# Altium schematic sheet Balance_Circuit.SchDoc
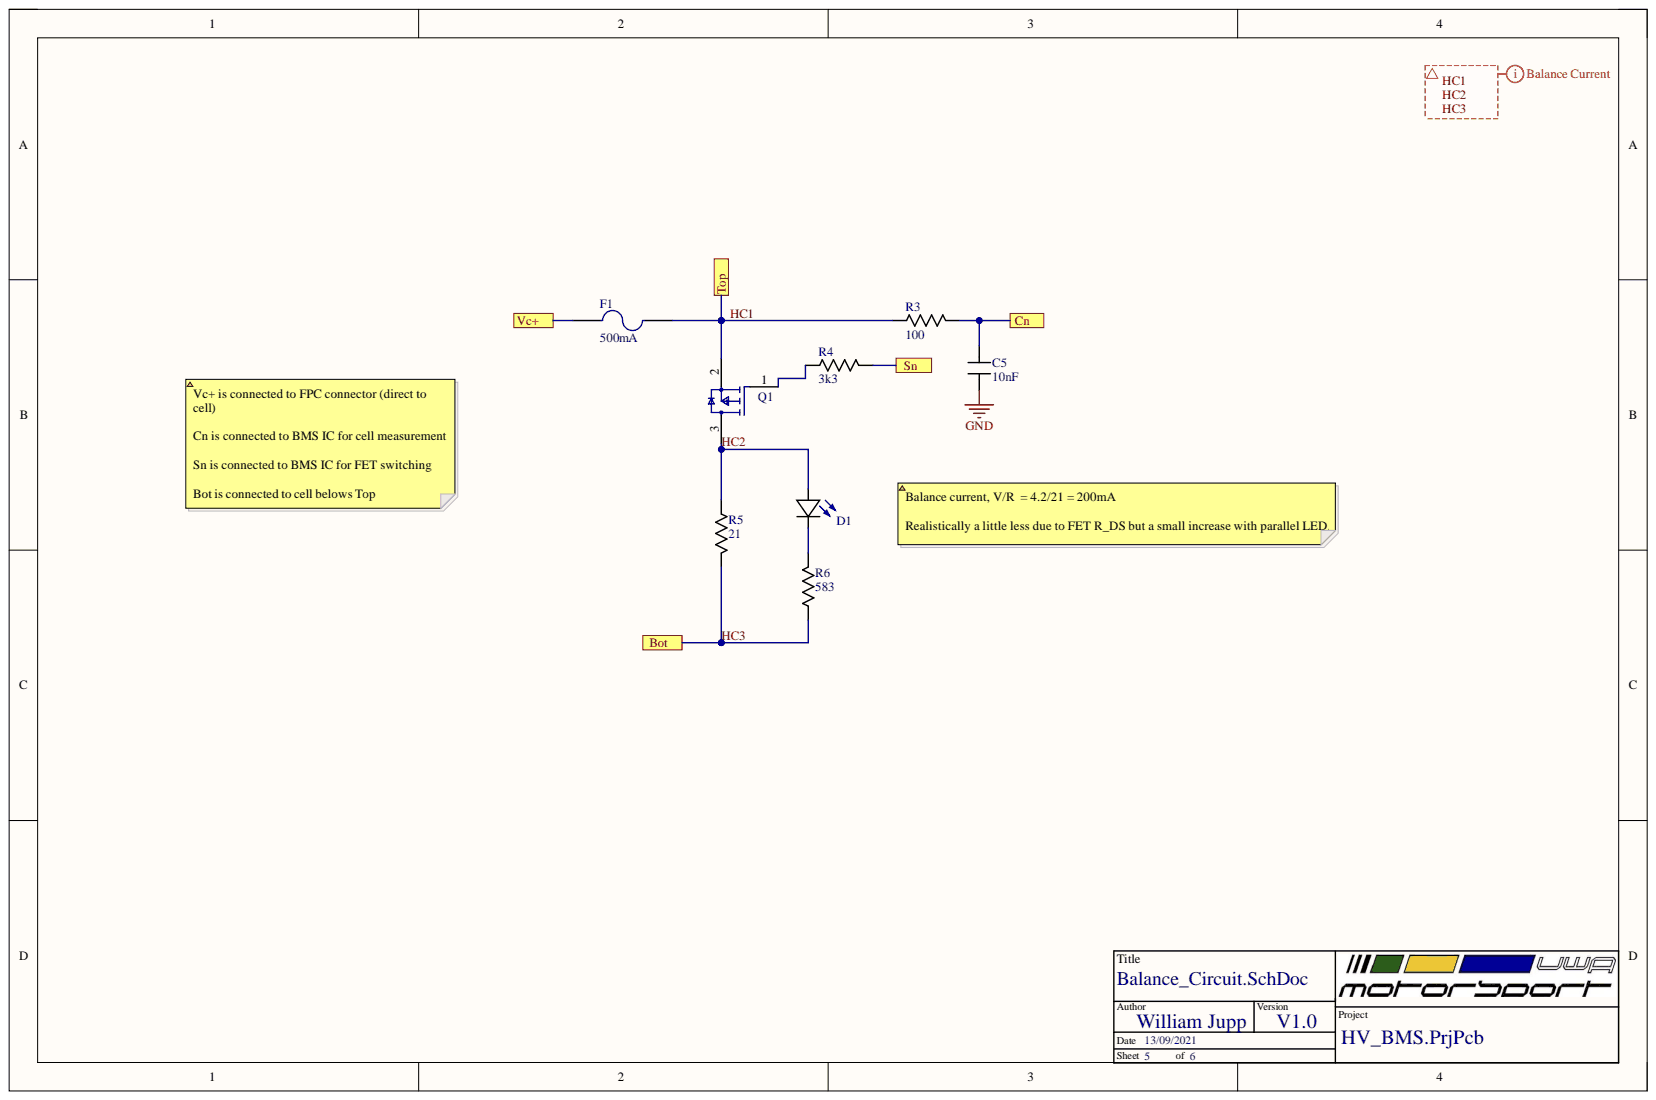
[diagram p0: Balance_Circuit.SchDoc]

## Parts
C5  C  value 10nF  [C_0603]
D1  150060BS55040  [LED (0603)]  (value not drawn)
F1  Current Rating: 500mA  [0466.500NR]  (hidden comment: 0466.500NR)
Q1  NX2301P,215  [FP-SOT23-MFG]  (value not drawn)
R3  value 100  [R-0603]  (hidden comment: Resistor)
R4  value 3k3  [R-0603]  (hidden comment: Resistor)
R5  value 21  [R_2512]  (hidden comment: CRCW251221R0FKEGHP)
R6  value 583  [R-0603]  (hidden comment: RT0603DRE07583RL)

## Ports
Bot, Cn, Sn, Top, Vc+

## Nets
GND: C5.1
Cn: C5.2 R3.2 ; port Cn
HC1: F1.2 Q1.2 R3.1 ; port Top
HC2: D1.2 Q1.3 R5.1
HC3: R5.2 R6.1 ; port Bot
Sn: R4.2 ; port Sn
Vc+: F1.1 ; port Vc+
NetD1_1: D1.1 R6.2
NetQ1_1: Q1.1 R4.1

## Library data of the parts
C5 = Capacitor: Component Kind: Standard; ComponentLink1Description: New link; Description: Capacitor; Library Name: Passives.IntLib
D1 = 150060BS55040: LED BLUE DIFFUSED 0603 SMD; Manufacturer: Wurth Electronics; Dominant Wavelength: 470nm; Forward Voltage: 3.2V; Lens Size: 0.8mm; Lens Style: Flat; Luminous Intensity: 80mcd; Test Current: 20mA; Viewing Angle: 140°; Case/Package: 0603; Color: Blue; Component Kind: Standard; ComponentLink1Description: http://www.farnell.com/datasheets/2621640.pdf?src-supplier=Farnell; ComponentLink1URL: http://www.farnell.com/datasheets/2621640.pdf?src-supplier=Farnell; ComponentLink2Description: https://www.we-online.de/web/en/index.php/download/media/07_electronic_components/download_center_1/application_notes_berichte/ano002_leds___the_future_of_horticultural_lighting/ANO002b_EN_LEDs_The_Future_of_Horticultural_Lighting.pdf?src-supplier=Digi-Key; ComponentLink2URL: https://www.we-online.de/web/en/index.php/download/media/07_electronic_components/download_center_1/application_notes_berichte/ano002_leds___the_future_of_horticultural_lighting/ANO002b_EN_LEDs_The_Future_of_Horticultural_Lighting.pdf?src-supplier=Digi-Key; ComponentLink3Description: https://katalog.we-online.de/pbs/datasheet/150060BS55040.pdf?src-supplier=Digi-Key; ComponentLink3URL: https://katalog.we-online.de/pbs/datasheet/150060BS55040.pdf?src-supplier=Digi-Key; ComponentLink4Description: http://www.farnell.com/datasheets/2621640.pdf?src-supplier=Newark; ComponentLink4URL: http://www.farnell.com/datasheets/2621640.pdf?src-supplier=Newark; Height: 0.5mm; Length: 1.6mm; Lens Transparency: Diffused; Library Name: LEDLib.IntLib; Manufacturer Part Number: 150060BS55040; Mount: Surface Mount; Packaging: Tape and Reel; Pricing 1: 1=0.18000, 10=0.16800, 50=0.16800, 100=0.15200, 1000=0.12100, 10000=0.10600 (USD); RoHS: No; RoHS Compliant: No; Supplier 1: Mouser; Supplier Part Number 1: 710-150060BS55040; Width: 0.8mm
F1 = Fuse 2: LITTELFUSE - 0466.500NR - Fuse, Surface Mount, 500 mA, 466 Series, 63 VAC, 63 VDC, Very Fast Acting, 1206; Approvals: UL,CSA; Body Material: Thermoplastic; DC Cold Resistance: 248mR; Fuse Type: Board Mount (Cartridge Style Excluded); Resistance: 350mR; Voltage Rating: 63V; Case Code (Imperial): 1206; Case Code (Metric): 3216; Case/Package: 1206; Category: Fuses; Component Kind: Standard; ComponentLink1Description: https://datasheet.ciiva.com/17081/0466-500nr-17081083.pdf?src-supplier=Allied+Electronics; ComponentLink1URL: https://datasheet.ciiva.com/17081/0466-500nr-17081083.pdf?src-supplier=Allied+Electronics; ComponentLink2Description: https://datasheet.ciiva.com/16327/littelfuse-fuse-466-16327478.pdf?src-supplier=Future+Electronics; ComponentLink2URL: https://datasheet.ciiva.com/16327/littelfuse-fuse-466-16327478.pdf?src-supplier=Future+Electronics; ComponentLink3Description: https://datasheet.ciiva.com/299/466seriesdrawing-299207.pdf?src-supplier=Digi-Key; ComponentLink3URL: https://datasheet.ciiva.com/299/466seriesdrawing-299207.pdf?src-supplier=Digi-Key; ComponentLink4Description: https://datasheet.ciiva.com/299/466seriesdrawing-299208.pdf?src-supplier=Digi-Key; ComponentLink4URL: https://datasheet.ciiva.com/299/466seriesdrawing-299208.pdf?src-supplier=Digi-Key; ComponentLink5Description: https://datasheet.ciiva.com/299/466seriesdrawing-299209.pdf?src-supplier=Digi-Key; ComponentLink5URL: https://datasheet.ciiva.com/299/466seriesdrawing-299209.pdf?src-supplier=Digi-Key; ComponentLink6Description: https://datasheet.ciiva.com/14102/ei466-dat-e-14102891.pdf?src-supplier=Distrelec; ComponentLink6URL: https://datasheet.ciiva.com/14102/ei466-dat-e-14102891.pdf?src-supplier=Distrelec; ComponentLink7Description: https://datasheet.ciiva.com/15186/getdatasheetpartid-57351-15186924.pdf?src-supplier=Verical; ComponentLink7URL: https://datasheet.ciiva.com/15186/getdatasheetpartid-57351-15186924.pdf?src-supplier=Verical; Depth: 1.52mm; Fuse Current: 500mA; Height: 0.023inch; Housing Material: Ceramic; LatestRevisionDate: 17-Jul-2002; LatestRevisionNote: Re-released for DXP Platform.; Lead Free: Lead Free; Length: 3.18mm; Library Name: Miscellaneous Devices.IntLib; Manufacturer: Littelfuse; Manufacturer Part Number: 0466.500NR; Max Operating Temperature: 90°C; Min Operating Temperature: -55°C; Mount: Surface Mount; Number of Pins: 2; Package Quantity: 5000; PackageDescription: Thru-Hole; 2 Leads; PackageReference: PIN-W2/E2.8; Packaging: Tape and Reel; Pricing 1: 1=0.77000, 10=0.59200, 50=0.59200, 100=0.48900, 1000=0.41600, 10000=0.39000 (USD); Published: 8-Jun-2000; Radiation Hardening: No; REACH SVHC: Unknown; RoHS: Yes; RoHS Compliant: Yes; Series: 466; Simulation: FUSE; Stock 1: 36497; Supplier 1: Mouser; Supplier Part Number 1: 576-0466.500NR; Terminal Material: Copper; Termination: SMD/SMT; Voltage Rating (AC): 63V; Voltage Rating (DC): 63V; Width: 0.06inch; Working Voltage: 63V; Publisher: Altium Limited
Q1 = CMP-14409-000018-1: MOSFET P-CH 20V 2A TO-236AB; Component Kind: Standard; Library Name: Altium Content Vault
R5 = Resistor: Balance Resistor; Component Kind: Standard; Library Name: Passives.IntLib; Supplier 1: Mouser; Supplier Part Number 1: 71-CRCW251221R0FKEGH
R6 = Resistor: Component Kind: Standard; Description: Resistor; Library Name: Passives.IntLib; Supplier 1: Mouser; Supplier Part Number 1: 603-RT0603DRE07583RL
R3 R4 = Resistor: Component Kind: Standard; Description: Resistor; Library Name: Passives.IntLib
